# Altium schematic sheet TrianglePowerSupplyMB-Rev2b.SchDoc(TrianglePowerSupplyMB-Rev2b)
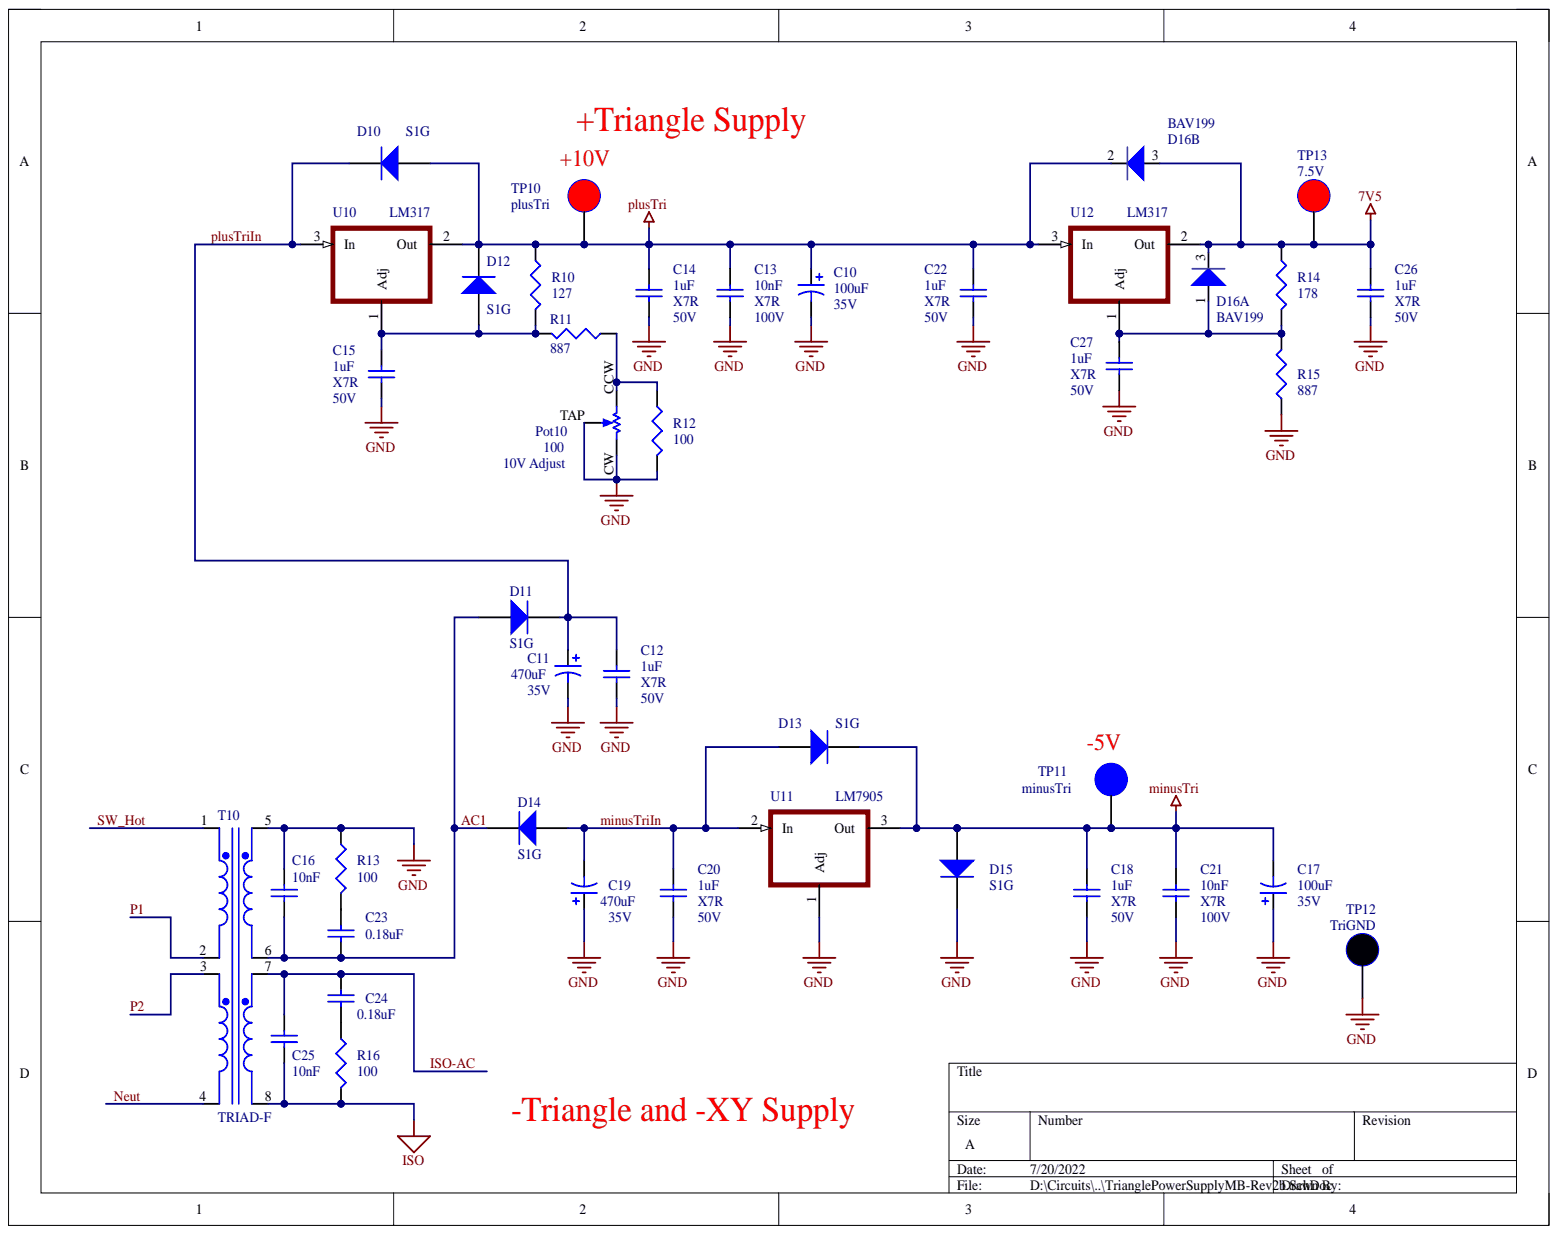
[diagram p0: TrianglePowerSupplyMB-Rev2b.SchDoc]

## Parts
C10  100uF  Voltage: 35V  [CAPPR2.5-6.3X10]
C11  470uF  Voltage: 35V  [CAPPR5-10x12.5-STEP]
C12  1uF  Dielectric: X7R  Voltage: 50V  [CAPC2012M]
C13  10nF  Dielectric: X7R  Voltage: 100V  [CAPC2012M]
C14  1uF  Dielectric: X7R  Voltage: 50V  [CAPC2012M]
C15  1uF  Dielectric: X7R  Voltage: 50V  [CAPC2012M]
C16  10nF  [CAPC3216M]
C17  100uF  Voltage: 35V  [CAPPR2.5-6.3X10]
C18  1uF  Dielectric: X7R  Voltage: 50V  [CAPC2012M]
C19  470uF  Voltage: 35V  [CAPPR5-10x12.5-STEP]
C20  1uF  Dielectric: X7R  Voltage: 50V  [CAPC2012M]
C21  10nF  Dielectric: X7R  Voltage: 100V  [CAPC2012M]
C22  1uF  Dielectric: X7R  Voltage: 50V  [CAPC2012M]
C23  0.18uF  [CAPC4532M]
C24  0.18uF  [CAPC4532M]
C25  10nF  [CAPC3216M]
C26  1uF  Dielectric: X7R  Voltage: 50V  [CAPC2012M]
C27  1uF  Dielectric: X7R  Voltage: 50V  [CAPC2012M]
D10  S1G  [SMA-D]
D11  S1G  [SMA-D]
D12  S1G  [SMA-D]
D13  S1G  [SMA-D]
D14  S1G  [SMA-D]
D15  S1G  [SMA-D]
D16  BAV199  [SOT23-3M]  (parts A,B)
Pot10  10V Adjust  value 100  [3306P-CCW]
R10  value 127  [RESC2012M]  (hidden comment: 1K)
R11  value 887  [RESC2012M]  (hidden comment: 6.81K)
R12  value 100  [RESC2012M]  (hidden comment: 1K)
R13  10  value 100  [RESC6432BM]
R14  value 178  [RESC2012M]  (hidden comment: 1K)
R15  value 887  [RESC2012M]  (hidden comment: 6.81K)
R16  100  [RESC6432BM]
T10  TRIAD-F  [TRIAD-6W]
TP10  plusTri  [TP-.038]
TP11  minusTri  [TP-.038]
TP12  TriGND  [TP-.038]
TP13  7.5V  [TP-.038]
U10  LM317  [D2PAK_M]
U11  LM7905  [DPAK-M]
U12  LM317  [SOT89M]

## Nets
7V5: C26.1 D16.3 R14.1 TP13.1 U12.2
GND: C10.2 C11.2 C12.2 C13.2 C14.2 C15.2 C16.1 C17.1 C18.2 C19.1 C20.2 C21.2 C22.2 C26.2 C27.2 D15.2 Pot10.CW Pot10.TAP R12.2 R13.1 R15.2 T10.5 TP12.1 U11.1
ISO: C25.2 R16.2 T10.8
minusTri: C17.2 C18.1 C21.1 D13.2 D15.1 TP11.1 U11.3
plusTri: C10.1 C13.1 C14.1 C22.1 D10.1 D12.2 D16.2 R10.1 TP10.1 U10.2 U12.3
AC1: C16.2 C23.1 D11.1 D14.2 T10.6
ISO-AC: C24.1 C25.1 T10.7
minusTriIn: C19.2 C20.1 D13.1 D14.1 U11.2
Neut: T10.4
P1: T10.2
P2: T10.3
plusTriIn: C11.1 C12.1 D10.2 D11.2 U10.3
SW_Hot: T10.1
NetC15_1: C15.1 D12.1 R10.2 R11.1 U10.1
NetC23_2: C23.2 R13.2
NetC24_2: C24.2 R16.1
NetC27_1: C27.1 D16.1 R14.2 R15.1 U12.1
NetPot10_CCW: Pot10.CCW R11.2 R12.1

## Library data of the parts
C10 C17 = Cap Pol1: CAP ALUM 100UF 20% 35V THRU HOLE; Manufacturer: Rubycon; Manufacturer Part Number: 35YXJ100M6.3X11; Impedance: 220mR; Lead Diameter: 0.5mm; Leakage Current: 3uA; Life (Hours): 7000Hour; Polarity: Polar; Ripple Current: 400mA; Capacitance: 100uF; Case/Package: Radial; Component Kind: Standard; Diameter: 6.3mm; Height: 11mm; Height - Seated (Max): 0.492inch; Lead Pitch: 2.5mm; Library Name: Miscellaneous Devices.IntLib; Max Operating Temperature: 105°C; Min Operating Temperature: -40°C; Mount: Through Hole; Package Quantity: 3000; Packaging: Bulk; Radiation Hardening: No; Signal Integrity: Cap Pol; Simulation: CAP; Supplier: Digi-Key; Supplier Part Number: 1189-2260-ND; Termination: Radial; Tolerance: 20%; Value: 100uF; Voltage Rating: 35V
C11 C19 = Cap Pol3: CAP ALUM 470UF 20% 35V RADIAL; Manufacturer: Rubycon; Manufacturer Part Number: 35ZL470MEFC10X20; Dielectric Material: Aluminium; Impedance: 23mR; Lead Diameter: 0.6mm; Leakage Current: 3uA; Life (Hours): 4000Hour; Ripple Current: 1.82A; Capacitance: 470uF; Case/Package: Radial; Component Kind: Standard; Diameter: 10mm; Height: 20mm; Height - Seated (Max): 0.866inch; Lead Free: Lead Free; Lead Pitch: 5mm; Library Name: Miscellaneous Devices.IntLib; Max Operating Temperature: 105°C; Min Operating Temperature: -40°C; Mount: Through Hole; Package Quantity: 1000; Packaging: Bulk; Radiation Hardening: No; REACH SVHC: Unknown; Signal Integrity: Cap Pol; Simulation: CAP; Supplier: Digi-Key; Supplier Part Number: 35ZL470MEFC10X20-ND; Termination: Radial; Tolerance: 20%; Value: 470uF; Voltage Rating: 35V
C12 C14 C15 C18 C20 C22 C26 C27 = Cap: CAP CER 1UF 50V X7R 0805; Manufacturer: Samsung; Manufacturer Part Number: CL21B105KBFNNNE; Dielectric Material: Ceramic; Tolerance: 10%; Capacitance: 1uF; Case Code (Imperial): 0805; Case Code (Metric): 2012; Case/Package: 0805; Component Kind: Standard; Construction: Flat; Depth: 1.25mm; Height: 1.25mm; Length: 2mm; Library Name: Miscellaneous Devices.IntLib; Max Operating Temperature: 125°C; Min Operating Temperature: -55°C; Mount: Surface Mount; Number of Pins: 2; Package Quantity: 1; Packaging: Tape and Reel; Radiation Hardening: No; REACH SVHC: No SVHC; RoHS Compliant: Yes; Series: CL; Signal Integrity: Cap; Simulation: CAP; Supplier: Digi-Key; Supplier Part Number: 1276-1029-6-ND; Termination: SMD/SMT; Thickness: 0.053inch; Value: 1uF; Voltage Rating: 50V; Voltage Rating (DC): 50V; Width: 0.049inch
C13 C21 = Cap: CAP CER 10NF 100V X7R 0805; Manufacturer: Samsung; Manufacturer Part Number: CL21B103KCANNNC; Dielectric Material: Ceramic; Dissipation Factor: 2.5%; Tolerance: 10%; Capacitance: 10nF; Case Code (Imperial): 0805; Case Code (Metric): 2012; Case/Package: 0805; Component Kind: Standard; Construction: Flat; Contact Plating: Tin; Depth: 1.25mm; Height: 0.65mm; Length: 2mm; Library Name: Miscellaneous Devices.IntLib; Material: Ceramic; Max Operating Temperature: 125°C; Min Operating Temperature: -55°C; Mount: Surface Mount; Number of Pins: 2; Package Quantity: 1; Packaging: Tape and Reel; Radiation Hardening: No; REACH SVHC: No SVHC; RoHS Compliant: Yes; Series: CL; Signal Integrity: Cap; Simulation: CAP; Supplier: Digi-Key; Supplier Part Number: 1276-1249-6-ND; Termination: SMD/SMT; Thickness: 0.03inch; Value: 10nF; Voltage Rating: 100V; Voltage Rating (DC): 100V; Width: 0.049inch
C16 C25 = Cap: CAP PEN 10NF 100V 5% 1206; Manufacturer: Kyocera AVX; Manufacturer Part Number: CB017E0103JBA; Voltage Rating (AC): 63V; Capacitance: 10nF; Case Code (Imperial): 1206; Case Code (Metric): 3216; Case/Package: 1206; Component Kind: Standard; Contact Plating: Tin,Matte; Depth: 1.6mm; Length: 3.3mm; Library Name: Miscellaneous Devices.IntLib; Max Operating Temperature: 125°C; Min Operating Temperature: -55°C; Mount: Surface Mount; Package Quantity: 3900; Packaging: Tape and Reel; Radiation Hardening: No; REACH SVHC: No SVHC; Signal Integrity: Cap; Simulation: CAP; Supplier: Digi-Key; Supplier Part Number: 478-13090-1-ND; Termination: SMD/SMT; Tolerance: 5%; Value: 10nF; Voltage Rating: 100V; Voltage Rating (DC): 100V
C23 C24 = Cap: CAP FILM 0.18UF 5% 50VDC 1812; Manufacturer: KEMET; Manufacturer Part Number: LDECC3180JA5N00; Capacitance: 0.18uF; Component Kind: Standard; Library Name: Miscellaneous Devices.IntLib; Signal Integrity: Cap; Simulation: CAP; Supplier: Digi-Key; Supplier Part Number: 399-18519-1-ND; Value: 0.18uF
D10-D15 = Diode 1N4002: Diode Switching 400V 1A 2-Pin DO-214AC T/R; Manufacturer: ON Semiconductor / Fairchild; Capacitance: 12pF; Element Configuration: Single; Forward Voltage: 1.1V; Max Forward Surge Current (Ifsm): 30A; Max Surge Current: 40A; Peak Reverse Current: 1uA; Polarity: Standard; Power Dissipation: 1.4W; Recovery Time: 1800ns; Reverse Recovery Time: 1.8us; Average Rectified Current: 1A; Case/Package: SMA; Component Kind: Standard; ComponentLink1Description: Manufacturer Link; ComponentLink1URL: http://www.fairchildsemi.com/; ComponentLink2Description: Datasheet; ComponentLink2URL: http://www.fairchildsemi.com/ds/1N%2F1N4001.pdf; Contact Plating: Tin,Matte; Current Rating: 1A; Forward Current: 1A; Height: 2.2mm; Lead Free: Lead Free; Length: 4.75mm; Library Name: Miscellaneous Devices.IntLib; Manufacturer Part Number: S1G; Max Operating Temperature: 150°C; Max Repetitive Reverse Voltage (Vrrm): 400V; Max Reverse Voltage (DC): 400V; Min Operating Temperature: -55°C; Mount: Surface Mount; Number of Pins: 2; Output Current: 1A; Package Quantity: 1; Packaging: Tape and Reel; Peak Non-Repetitive Surge Current: 30A; Radiation Hardening: No; REACH SVHC: No SVHC; Reverse Voltage: 400V; Signal Integrity: 1N4002; Simulation: 1N4002; Supplier: Digi-Key; Supplier Part Number: S1GFSCT-ND; Value: S1G; Voltage Rating (DC): 400V; Weight: 0.106g; Width: 2.95mm
D16 = Dual-Si-Series-Separate: DIODE SI 85V 0.16mA SOT-23-3; Manufacturer: Nexperia; Manufacturer Part Number: BAV199,215; Component Kind: Standard; Library Name: MY_Diodes.SchLib; RoHS Compliant: Yes; Supplier: Digi-Key; Supplier Part Number: 1727-2909-6-ND; Value: BAV199
Pot10 = POT-Single: TRIMMER 100 OHM 0.2W TH; Manufacturer: Bourns; Manufacturer Part Number: 3306P-1-101; Lead Diameter: 1mm; Max Power Dissipation: 200mW; Number of Turns: 1; Pitch: 2.5mm; Resistance: 100R; Temperature Coefficient: 250ppm/°C; Tolerance: 25%; Voltage Rating: 100V; Color: Blue; Colour: Blue; Component Kind: Standard; Composition: Cermet; Depth: 8.1mm; Diameter: 6.81mm; Height: 7.62mm; Lead Free: Lead Free; Length: 6.81mm; Max Operating Temperature: 100°C; Military Standard: Not; Min Operating Temperature: -25°C; Mount: Through Hole,PCB; Number of Terminals: 3; Package Quantity: 200; Packaging: Bulk; Power Rating: 200mW; Radiation Hardening: No; REACH SVHC: No SVHC; Supplier: Digi-Key; Supplier Part Number: 3306P-101-ND; Taper: Linear; Termination: Pin; Type: Potentiometer; Voltage Rating (DC): 100V; Weight: 360mg; Width: 6.81mm; Library Name: MY_POTS.SchLib
R10 = Res3: Res Thick Film 0805 127 Ohm 1% 0.25W(1/4W) ±100ppm/C Pad SMD Automotive T/R; Manufacturer: KOA Speer; Manufacturer Part Number: RK73H2ATTD1270F; Power Rating: 125mW; Resistance: 127R; Temperature Coefficient: 100ppm/°C; Voltage Rating: 150V; Case Code (Imperial): 0805; Case Code (Metric): 2012; Case/Package: 0805; Category: Chip SMD Resistors; Component Kind: Standard; ComponentLink1Description: http://components.arrow.com/part/dataview/39727021S7403937N5146?src-supplier=Arrow+Electronics; ComponentLink1URL: http://components.arrow.com/part/dataview/39727021S7403937N5146?src-supplier=Arrow+Electronics; ComponentLink2Description: http://www.koaspeer.com/catimages/Products/RK73H/RK73H.pdf?src-supplier=Mouser; ComponentLink2URL: http://www.koaspeer.com/catimages/Products/RK73H/RK73H.pdf?src-supplier=Mouser; Height: 0.5mm; Lead Free: Lead Free; Length: 2mm; Library Name: Miscellaneous Devices.IntLib; Max Operating Temperature: 155°C; Min Operating Temperature: -55°C; Package Quantity: 5000; Packaging: Tape and Reel; Pricing 1: 1=0.10000, 10=0.04200, 100=0.01710, 1000=0.00768, 2500=0.00666 (USD); RoHS: Yes; RoHS Compliant: Yes; Series: RK73H; Signal Integrity: Res; Simulation: RESISTOR; Supplier: Digi-Key; Supplier Part Number: 2019-RK73H2ATTD1270FCT-ND; Termination: SMD/SMT; Tolerance: 1%; Voltage Rating (DC): 150V; Width: 1.25mm
R11 = Res3: Res Thick Film 0805 887 Ohm 1% 0.25W(1/4W) ±100ppm/C Pad SMD Automotive T/R; Manufacturer: KOA Speer; Manufacturer Part Number: RK73H2ATTD8870F; Power Rating: 125mW; Resistance: 887R; Temperature Coefficient: 100ppm/°C; Voltage Rating: 150V; Case Code (Imperial): 0805; Case Code (Metric): 2012; Case/Package: 0805; Category: Chip SMD Resistors; Component Kind: Standard; ComponentLink1Description: http://www.mouser.com/ds/2/219/RK73H-5715.pdf?src-supplier=Mouser; ComponentLink1URL: http://www.mouser.com/ds/2/219/RK73H-5715.pdf?src-supplier=Mouser; Construction: Rectangular; Depth: 1.25mm; Height: 0.5mm; Lead Free: Lead Free; Length: 2mm; Library Name: Miscellaneous Devices.IntLib; Max Operating Temperature: 155°C; Military Standard: Not; Min Operating Temperature: -55°C; Package Quantity: 5000; Packaging: Tape and Reel; Pricing 1: 1=0.10000, 10=0.04200, 100=0.01710, 1000=0.00768, 2500=0.00666 (USD); Resistor Type: Thick Film; RoHS: Yes; RoHS Compliant: Yes; Series: RK73H; Signal Integrity: Res; Simulation: RESISTOR; Stock 1: 14310; Supplier: Digi-Key; Supplier Part Number: 2019-RK73H2ATTD8870FCT-ND; Termination: SMD/SMT; Tolerance: 1%; Voltage Rating (DC): 150V; Width: 1.25mm
R12 = Res3: Res Thick Film 0805 100 Ohm 1% 0.25W; Manufacturer: KOA Speer; Manufacturer Part Number: RK73H2ATTD1000F; Power Rating: 125mW; Resistance: 100R; Temperature Coefficient: 100ppm/°C; Voltage Rating: 150V; Case Code (Imperial): 0805; Case Code (Metric): 2012; Case/Package: 0805; Category: Chip SMD Resistors; Component Kind: Standard; ComponentLink1Description: https://datasheet.ciiva.com/7773/549017-7773391.pdf?src-supplier=Element14; ComponentLink1URL: https://datasheet.ciiva.com/7773/549017-7773391.pdf?src-supplier=Element14; Construction: Rectangular; Depth: 1.25mm; Height: 0.5mm; Lead Free: Lead Free; Length: 2mm; Library Name: Miscellaneous Devices.IntLib; Max Operating Temperature: 155°C; Military Standard: Not; Min Operating Temperature: -55°C; Mount: Surface Mount; Number of Pins: 2; Package Quantity: 5000; Packaging: Tape and Reel; Pricing 1: 1=0.10000, 10=0.04200, 100=0.01710, 1000=0.00768, 2500=0.00666 (USD); REACH SVHC: Unknown; Resistance Tolerance: 1%; Resistor Type: Thick Film; RoHS: Yes; RoHS Compliant: Yes; Series: RK73H; Signal Integrity: Res; Simulation: RESISTOR; Supplier: Digi-Key; Supplier Part Number: 2019-RK73H2ATTD1000FCT-ND; Technology: THICK FILM; Termination: SMD/SMT; Tolerance: 1%; Voltage Rating (DC): 150V; Width: 1.25mm
R13 R16 = Res3: RES 100 OHM 1% 1W 2512; Manufacturer: Stackpole Electronics; Manufacturer Part Number: RMCF2512FT100R; Resistance: 100R; Temperature Coefficient: 100ppm/°C; Case Code (Imperial): 2512; Case Code (Metric): 6432; Case/Package: 2512; Component Kind: Standard; Composition: Thick Film; Contact Plating: Tin,Matte; Features: Automotive AEC-Q200; Height: 0.028inch; Lead Free: Lead Free; Length: 0.248inch; Library Name: Miscellaneous Devices.IntLib; Max Operating Temperature: 155°C; Max Power Dissipation: 1W; Min Operating Temperature: -55°C; Mount: Surface Mount; Number of Terminations: 2; Packaging: Tape and Reel; Power Rating: 1W; Radiation Hardening: No; Signal Integrity: Res; Simulation: RESISTOR; Supplier: Digi-Key; Supplier Part Number: RMCF2512FT100RCT-ND; Tolerance: 1%; Width: 0.126inch
  R16: Value: 100
R14 = Res3: Res Thick Film 0805 178 Ohm 1% 1/8W ±100ppm/C Molded SMD Punched Paper T/R; Manufacturer: KOA Speer; Manufacturer Part Number: RK73H2ATTD1780F; Power Rating: 125mW; Resistance: 178R; Temperature Coefficient: 100ppm/°C; Voltage Rating: 150V; Case Code (Imperial): 0805; Case Code (Metric): 2012; Case/Package: 0805; Category: Chip SMD Resistors; Component Kind: Standard; ComponentLink1Description: http://components.arrow.com/part/dataview/39727021S7403937N5146?src-supplier=Arrow+Electronics; ComponentLink1URL: http://components.arrow.com/part/dataview/39727021S7403937N5146?src-supplier=Arrow+Electronics; ComponentLink2Description: http://www.koaspeer.com/catimages/Products/RK73H/RK73H.pdf?src-supplier=Mouser; ComponentLink2URL: http://www.koaspeer.com/catimages/Products/RK73H/RK73H.pdf?src-supplier=Mouser; ComponentLink3Description: http://components.arrow.com/part/dataview/39727096S7740551N5146?src-supplier=Arrow+Electronics; ComponentLink3URL: http://components.arrow.com/part/dataview/39727096S7740551N5146?src-supplier=Arrow+Electronics; Height: 0.5mm; Lead Free: Lead Free; Length: 2mm; Library Name: Miscellaneous Devices.IntLib; Max Operating Temperature: 155°C; Min Operating Temperature: -55°C; Package Quantity: 5000; Packaging: Tape and Reel; Pricing 1: 1=0.10000, 10=0.04200, 100=0.01710, 1000=0.00768, 2500=0.00666 (USD); RoHS: Yes; RoHS Compliant: Yes; Series: RK73H; Signal Integrity: Res; Simulation: RESISTOR; Stock 1: 464; Supplier: Digi-Key; Supplier Part Number: 2019-RK73H2ATTD1780FCT-ND; Supplier Part Number 1: 2019-RK73H2ATTD1780FCT-ND; Termination: SMD/SMT; Tolerance: 1%; Voltage Rating (DC): 150V; Width: 1.25mm
R15 = Res3: Res Thick Film 0805 887 Ohm 1% 0.25W(1/4W) ±100ppm/C Pad SMD Automotive T/R; Manufacturer: KOA Speer; Manufacturer Part Number: RK73H2ATTD8870F; Power Rating: 125mW; Resistance: 887r; Temperature Coefficient: 100ppm/°C; Voltage Rating: 150V; Case Code (Imperial): 0805; Case Code (Metric): 2012; Case/Package: 0805; Category: Chip SMD Resistors; Component Kind: Standard; ComponentLink1Description: http://www.mouser.com/ds/2/219/RK73H-5715.pdf?src-supplier=Mouser; ComponentLink1URL: http://www.mouser.com/ds/2/219/RK73H-5715.pdf?src-supplier=Mouser; Construction: Rectangular; Depth: 1.25mm; Height: 0.5mm; Lead Free: Lead Free; Length: 2mm; Library Name: Miscellaneous Devices.IntLib; Max Operating Temperature: 155°C; Military Standard: Not; Min Operating Temperature: -55°C; Mount: Surface Mount; Number of Pins: 2; Package Quantity: 5000; Packaging: Tape and Reel; Pricing 1: 1=0.10000, 10=0.04200, 100=0.01710, 1000=0.00768, 2500=0.00666 (USD); Resistance Tolerance: 1%; Resistor Type: Thick Film; RoHS: Yes; RoHS Compliant: Yes; Series: RK73H; Signal Integrity: Res; Simulation: RESISTOR; Stock 1: 1030; Supplier: Digi-Key; Supplier Part Number: 2019-RK73H2ATTD8870FCT-ND; Technology: THICK FILM; Termination: SMD/SMT; Tolerance: 1%; Voltage Rating (DC): 150V; Width: 1.25mm
T10 = TRIAD-F: POWER XFMR 28 Vct @ 200 mA UL/cUL - Class 2/3, TUV, 115/230V SPLIT PACK PCB MOUNT / FS28-200-C2; Manufacturer: Triad Magnetics; Frequency: 50Hz; Max Output Current: 200mA; Max Power Dissipation: 6W; Primary Winding(s): Dual; Category: Uncategorized; Component Kind: Standard; ComponentLink1Description: https://datasheet.ciiva.com/24117/s28-200-c2-263348-24117094.pdf?src-supplier=Mouser; ComponentLink1URL: https://datasheet.ciiva.com/24117/s28-200-c2-263348-24117094.pdf?src-supplier=Mouser; Height: 1.312inch; Height - Seated (Max): 33.32mm; Lead Free: Lead Free; Length: 41.28mm; Library Name: My_Trans.SchLib; Manufacturer Part Number: FS28-200-C2; Number of Pins: 8; Package Quantity: 10; Packaging: Rail/Tube; Power Rating: 6W; Pricing 1: 1=8.23000, 10=6.40100, 25=6.22800, 50=5.36300, 100=5.12080, 250=5.01700, 500=4.93050, 1000=4.84400 (USD); RoHS: Yes; RoHS Compliant: Yes; Secondary Current: 200mA; Secondary Winding(s): Dual; Stock 1: 381; Supplier: Digi-Key; Supplier Part Number: 237-1501-5-ND; Termination: PC Pin; Value: FS28-200-C2; Weight: 0.44lb; Width: 33.32mm
TP10 TP13 = TP-Round-Red: TP Thru-Hole; Manufacturer: UW; Manufacturer Part Number: Gold; Component Kind: Standard; Library Name: MY_TP.SchLib; Supplier: UW; Supplier Part Num,ber: Gold; Value: TP Thru-Hole
TP11 = TP-Round-Blue: TP Thru-Hole; Manufacturer: UW; Manufacturer Part Number: Gold; Component Kind: Standard; Library Name: MY_TP.SchLib; Supplier: UW; Supplier Part Num,ber: Gold; Value: TP Thru-Hole
TP12 = TP-Round-Black: TP Thru-Hole; Manufacturer: UW; Manufacturer Part Number: Gold; Component Kind: Standard; Library Name: MY_TP.SchLib; Supplier: UW; Supplier Part Num,ber: Gold; Value: TP Thru-Hole
U10 = LM317-3Pin: IC REG LDO ADJ 0.5A TO252-3; Manufacturer: Texas Instruments; Manufacturer Part Number: LM317MKVURG3; Dropout Voltage: 2V; Max Input Voltage: 40V; Max Output Current: 500mA; Max Output Voltage: 37V; Min Input Voltage: 4.2V; Min Output Voltage: 1.25V; Number of Outputs: 1; Output Type: Adjustable; Polarity: Positive; Power Supply Rejection Ratio (PSRR): 80dB; Quiescent Current: 3.5mA; Reference Voltage: 1.3V; Case/Package: TO-252-3; Category: Voltage Regulators - Linear; Component Kind: Standard; ComponentLink1Description: https://datasheet.ciiva.com/22629/1716624-22629235.pdf?src-supplier=Element14; ComponentLink1URL: https://datasheet.ciiva.com/22629/1716624-22629235.pdf?src-supplier=Element14; ComponentLink2Description: https://datasheet.ciiva.com/46381/1814942-46381865.pdf?src-supplier=Element14; ComponentLink2URL: https://datasheet.ciiva.com/46381/1814942-46381865.pdf?src-supplier=Element14; ComponentLink3Description: https://datasheet.ciiva.com/2043/lm317m-2043128.pdf?src-supplier=Digi-Key; ComponentLink3URL: https://datasheet.ciiva.com/2043/lm317m-2043128.pdf?src-supplier=Digi-Key; ComponentLink4Description: https://datasheet.ciiva.com/2043/lm317m-2043127.pdf?src-supplier=Digi-Key; ComponentLink4URL: https://datasheet.ciiva.com/2043/lm317m-2043127.pdf?src-supplier=Digi-Key; ComponentLink5Description: https://datasheet.ciiva.com/2043/lm317m-2043129.pdf?src-supplier=Digi-Key; ComponentLink5URL: https://datasheet.ciiva.com/2043/lm317m-2043129.pdf?src-supplier=Digi-Key; Contact Plating: Tin; Height: 2.39mm; Lead Free: Lead Free; Length: 6.7mm; Max Operating Temperature: 125°C; Min Current Limit: 500mA; Min Operating Temperature: -40°C; Mount: Surface Mount; Number of Pins: 3; Number of Regulators: 1; Output Current: 500mA; Output Voltage: 37V; Package Quantity: 2500; Packaging: Tape and Reel; Pricing 1: 1=0.86000, 10=0.75900, 25=0.71320, 100=0.58200, 250=0.54056, 500=0.46004, 1000=0.37975 (USD); Radiation Hardening: No; REACH SVHC: No SVHC; RoHS: Yes; RoHS Compliant: Yes; Supplier: Digi-Key; Supplier Part Number: 296-19495-1-ND; Value: LM317; Weight: 0.011598oz; Width: 6.22mm; Library Name: MY_Vregs.SchLib
U11 = LM337-3Pin: LDO Regulator, 500 mA, 5 V, Negative 4%; TJ = -40°C to +125°C; Manufacturer: ON Semiconductor; Manufacturer Part Number: MC79M05BDTG; Dropout Voltage: 1.1V; Max Input Voltage: -35V; Max Output Current: 500mA; Max Output Voltage: -5.2V; Min Input Voltage: -7V; Min Output Voltage: -5V; Number of Outputs: 1; Output Type: Fixed; Power Supply Rejection Ratio (PSRR): 66dB; Quiescent Current: 8mA; Accuracy: 4%; Case/Package: DPAK; Category: Voltage Regulators - Linear; Component Kind: Standard; ComponentLink1Description: https://datasheet.ciiva.com/30477/0900766b8124f85a-30477364.pdf?src-supplier=RSComponents; ComponentLink1URL: https://datasheet.ciiva.com/30477/0900766b8124f85a-30477364.pdf?src-supplier=RSComponents; ComponentLink2Description: https://datasheet.ciiva.com/26907/mc79m05bdtg-26907424.pdf?src-supplier=IIIC; ComponentLink2URL: https://datasheet.ciiva.com/26907/mc79m05bdtg-26907424.pdf?src-supplier=IIIC; ComponentLink3Description: https://datasheet.ciiva.com/2044/mc79m00-d-2044392.pdf?src-supplier=Digi-Key; ComponentLink3URL: https://datasheet.ciiva.com/2044/mc79m00-d-2044392.pdf?src-supplier=Digi-Key; ComponentLink4Description: https://datasheet.ciiva.com/3224/mc79m00-d-3224126.pdf?src-supplier=Avnet; ComponentLink4URL: https://datasheet.ciiva.com/3224/mc79m00-d-3224126.pdf?src-supplier=Avnet; ComponentLink5Description: https://datasheet.ciiva.com/16382/mc79m00-d-16382026.pdf?src-supplier=Future+Electronics; ComponentLink5URL: https://datasheet.ciiva.com/16382/mc79m00-d-16382026.pdf?src-supplier=Future+Electronics; ComponentLink6Description: https://datasheet.ciiva.com/8073/mc79m00-d-8073727.pdf?src-supplier=Element14; ComponentLink6URL: https://datasheet.ciiva.com/8073/mc79m00-d-8073727.pdf?src-supplier=Element14; ComponentLink7Description: https://datasheet.ciiva.com/7992/1747960-7992683.pdf?src-supplier=Element14; ComponentLink7URL: https://datasheet.ciiva.com/7992/1747960-7992683.pdf?src-supplier=Element14; Contact Plating: Tin,Matte; Halogen Free: Halogen Free; Height: 2.38mm; Lead Free: Lead Free; Length: 6.73mm; Max Operating Temperature: 125°C; Min Operating Temperature: -40°C; Mount: Surface Mount; Nominal Output Voltage: -5V; Number of Pins: 3; Number of Regulators: 1; Output Current: 500mA; Output Voltage: -5V; Output Voltage Accuracy: 4%; Package Quantity: 75; Packaging: Rail/Tube; Polarity: Negative; Pricing 1: 1=0.72000, 10=0.63600, 25=0.59760, 100=0.48780, 250=0.45312, 500=0.38562, 1000=0.31832 (USD); Radiation Hardening: No; REACH SVHC: No SVHC; RoHS: Yes; Series: -; Stock 1: 92; Supplier: Digi-Key; Supplier Part Number: MC79M05BDTGOS-ND; Value: LM7905; Width: 6.22mm; Library Name: MY_Vregs.SchLib
U12 = LM317-3Pin: Linear Voltage Regulator IC Positive Adjustable 1 Output 1.2 V ~ 32 V 100mA SOT-89-3; Manufacturer: Texas Instruments; Manufacturer Part Number: LM317LIPK; Max Input Voltage: 35V; Max Output Voltage: 32V; Min Input Voltage: 3.7V; Min Output Voltage: 1.2V; Number of Outputs: 1; Power Supply Rejection Ratio (PSRR): 80dB; Quiescent Current: 1.5mA; Reference Voltage: 1.3V; Case/Package: SOT-89; Category: Voltage Regulators - Linear; Component Kind: Standard; ComponentLink1Description: https://datasheet.ciiva.com/22710/1831060-22710928.pdf?src-supplier=Element14; ComponentLink1URL: https://datasheet.ciiva.com/22710/1831060-22710928.pdf?src-supplier=Element14; ComponentLink2Description: https://datasheet.ciiva.com/15111/getdatasheetpartid-49894-15111116.pdf?src-supplier=Verical; ComponentLink2URL: https://datasheet.ciiva.com/15111/getdatasheetpartid-49894-15111116.pdf?src-supplier=Verical; ComponentLink3Description: https://datasheet.ciiva.com/1512/1859385-1512034.pdf?src-supplier=Element14; ComponentLink3URL: https://datasheet.ciiva.com/1512/1859385-1512034.pdf?src-supplier=Element14; ComponentLink4Description: https://datasheet.ciiva.com/46386/1859385-46386791.pdf?src-supplier=Element14; ComponentLink4URL: https://datasheet.ciiva.com/46386/1859385-46386791.pdf?src-supplier=Element14; ComponentLink5Description: https://datasheet.ciiva.com/2042/lm317l-2042778.pdf?src-supplier=Digi-Key; ComponentLink5URL: https://datasheet.ciiva.com/2042/lm317l-2042778.pdf?src-supplier=Digi-Key; ComponentLink6Description: https://datasheet.ciiva.com/2042/lm317l-2042777.pdf?src-supplier=Digi-Key; ComponentLink6URL: https://datasheet.ciiva.com/2042/lm317l-2042777.pdf?src-supplier=Digi-Key; ComponentLink7Description: https://datasheet.ciiva.com/2042/lm317l-2042779.pdf?src-supplier=Digi-Key; ComponentLink7URL: https://datasheet.ciiva.com/2042/lm317l-2042779.pdf?src-supplier=Digi-Key; ComponentLink8Description: https://datasheet.ciiva.com/3223/lm317l-3223686.pdf?src-supplier=Avnet; ComponentLink8URL: https://datasheet.ciiva.com/3223/lm317l-3223686.pdf?src-supplier=Avnet; ComponentLink9Description: https://datasheet.ciiva.com/48475/lm317l-48475020.pdf?src-supplier=Avnet; ComponentLink9URL: https://datasheet.ciiva.com/48475/lm317l-48475020.pdf?src-supplier=Avnet; Contact Plating: Tin,Matte; Height: 1.5mm; Lead Free: Lead Free; Length: 4.5mm; Max Operating Temperature: 125°C; Max Output Current: 100mA; Min Current Limit: 100mA; Min Operating Temperature: -40°C; Mount: Surface Mount; Number of Pins: 3; Number of Regulators: 1; Output Current: 100mA; Output Type: Adjustable; Output Voltage: 32V; Package Quantity: 1; Packaging: Tape and Reel; Polarity: Positive; Pricing 1: 1=0.74000, 10=0.65400, 25=0.61440, 100=0.50150, 250=0.46580, 500=0.39644 (USD); Radiation Hardening: No; REACH SVHC: No SVHC; RoHS: Yes; RoHS Compliant: Yes; Supplier: Digi-Key; Supplier Part Number: 296-21742-1-ND; Value: LM317; Weight: 0.004603oz; Width: 2.5mm; Library Name: MY_Vregs.SchLib
